# Altium schematic sheet Memo.SchDoc(Memo)
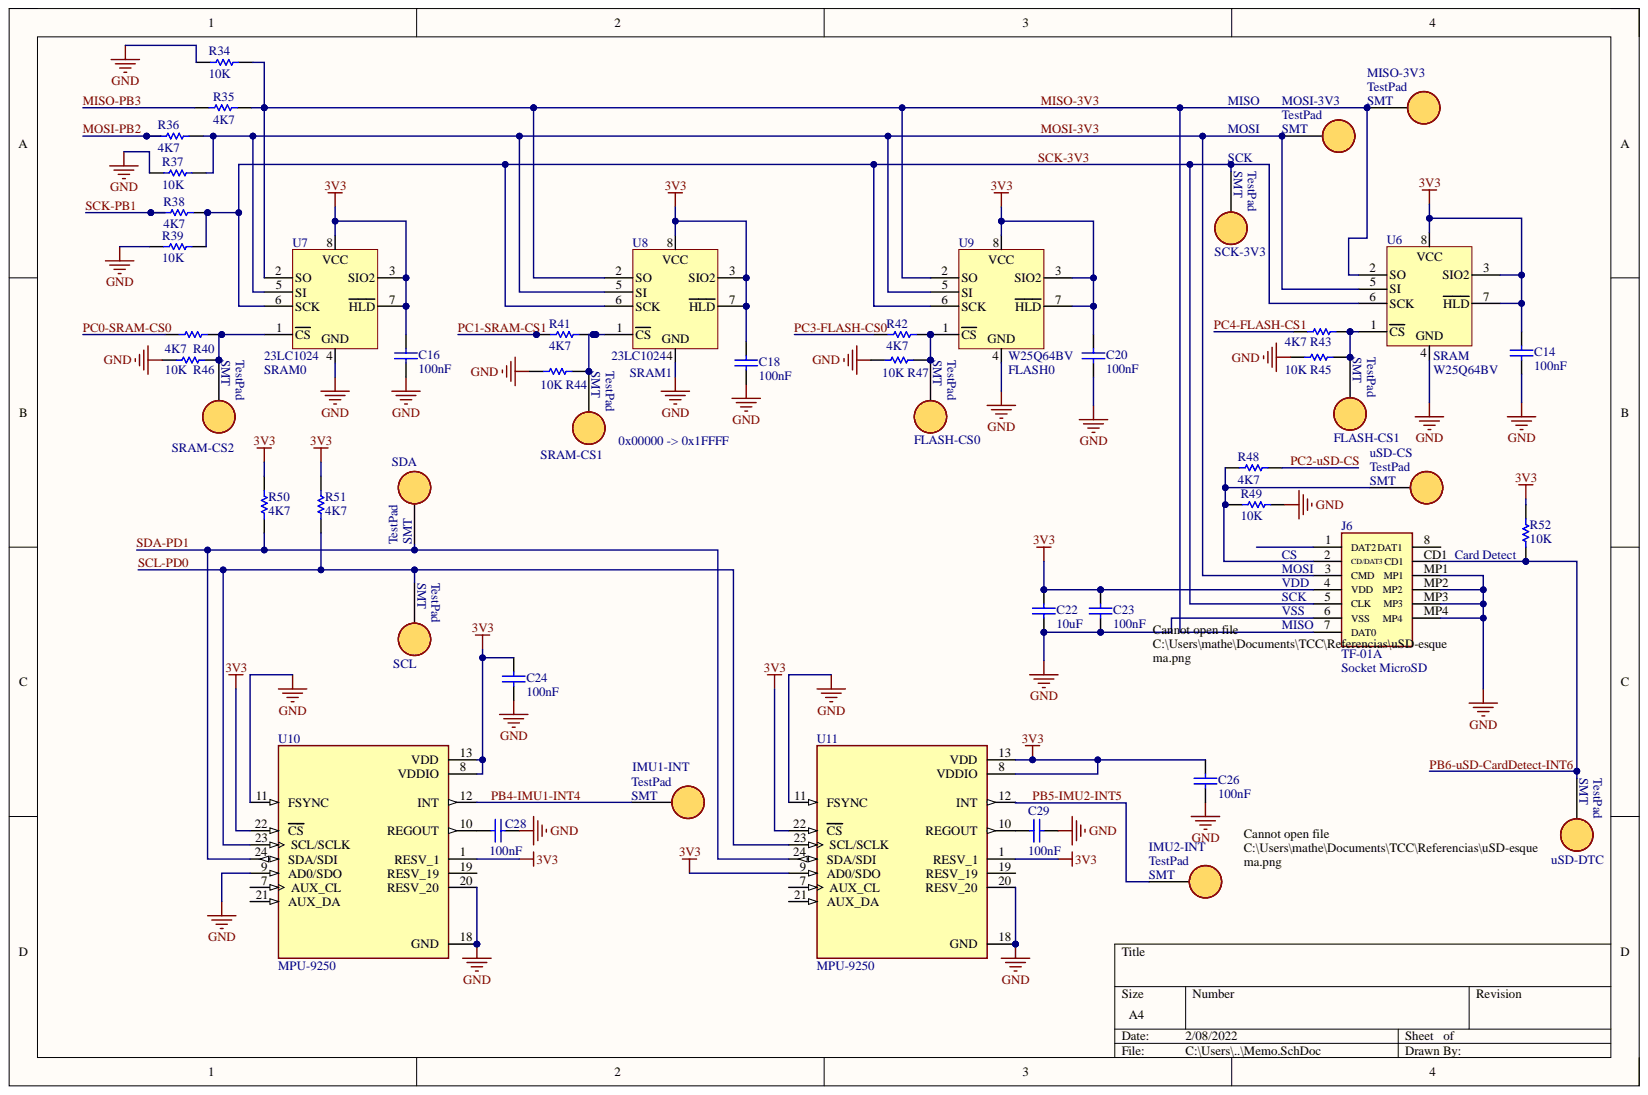
[diagram p0: Memo.SchDoc]

## Parts
C14  100nF  [CAPC2012X65N]
C16  100nF  [CAPC2012X65N]
C18  100nF  [CAPC2012X65N]
C20  100nF  [CAPC2012X65N]
C22  10uF  [CAPGC2012X65N]
C23  100nF  [CAPC2012X65N]
C24  100nF  [CAPC2012X65N]
C26  100nF  [CAPC2012X65N]
C28  100nF  [CAPC2012X65N]
C29  100nF  [CAPC2012X65N]
FLASH-CS0  (no value)  [TestPAD-SMT-SIMPLES]
FLASH-CS1  (no value)  [TestPAD-SMT-SIMPLES]
IMU1-INT  (no value)  [TestPAD-SMT-SIMPLES]
IMU2-INT  (no value)  [TestPAD-SMT-SIMPLES]
J6  TF-01A  [TF01A]
MISO-3V3  (no value)  [TestPAD-SMT-SIMPLES]
MOSI-3V3  (no value)  [TestPAD-SMT-SIMPLES]
R34  10K  [RESC2012X65N]
R35  4K7  [RESC2012X65N]
R36  4K7  [RESC2012X65N]
R37  10K  [RESC2012X65N]
R38  4K7  value 10K  [RESC2012X65N]
R39  10K  [RESC2012X65N]
R40  4K7  [RESC2012X65N]
R41  4K7  [RESC2012X65N]
R42  4K7  [RESC2012X65N]
R43  4K7  [RESC2012X65N]
R44  10K  [RESC2012X65N]
R45  10K  [RESC2012X65N]
R46  10K  [RESC2012X65N]
R47  10K  [RESC2012X65N]
R48  4K7  [RESC2012X65N]
R49  10K  [RESC2012X65N]
R50  4K7  [RESC2012X65N]
R51  4K7  [RESC2012X65N]
R52  10K  [RESC2012X65N]
SCK-3V3  (no value)  [TestPAD-SMT-SIMPLES]
SCL  (no value)  [TestPAD-SMT-SIMPLES]
SDA  (no value)  [TestPAD-SMT-SIMPLES]
SRAM-CS1  (no value)  [TestPAD-SMT-SIMPLES]
SRAM-CS2  (no value)  [TestPAD-SMT-SIMPLES]
U6  W25Q64BV  [SOIC127P790X216-8N]
U7  23LC1024  [SOIC127P600X175-8N]
U8  23LC1024  [SOIC127P600X175-8N]
U9  W25Q64BV  [SOIC127P790X216-8N]
U10  MPU-9250  [QFN40P300X300X105-24N]
U11  MPU-9250  [QFN40P300X300X105-24N]
uSD-CS  (no value)  [TestPAD-SMT-SIMPLES]
uSD-DTC  (no value)  [TestPAD-SMT-SIMPLES]

## Nets
3V3: C14.1 C16.1 C18.1 C20.1 C22.1 C23.1 C24.1 C26.1 J6.4(VDD) R50.2 R51.2 R52.2 U6.3(SIO2) U6.7(HLD) U6.8 U7.3(SIO2) U7.7(HLD) U7.8 U8.3(SIO2) U8.7(HLD) U8.8 U9.3(SIO2) U9.7(HLD) U9.8 U10.1(RESV_1) U10.8(VDDIO) U10.13(VDD) U10.22(CS) U11.1(RESV_1) U11.8(VDDIO) U11.9(AD0/SDO) U11.13(VDD) U11.22(CS)
GND: C14.2 C16.2 C18.2 C20.2 C22.2 C23.2 C24.2 C26.2 C28.2 C29.2 J6.6(VSS) J6.MP1 J6.MP2 J6.MP3 J6.MP4 R34.1 R37.1 R39.1 R44.2 R45.2 R46.2 R47.2 R49.2 U6.4 U7.4 U8.4 U9.4 U10.9(AD0/SDO) U10.11(FSYNC) U10.18(GND) U10.20(RESV_20) U11.11(FSYNC) U11.18(GND) U11.20(RESV_20)
MISO-3V3: J6.7(DAT0) MISO-3V3.1 R34.2 R35.2 U6.2(SO) U7.2(SO) U8.2(SO) U9.2(SO)
MISO-PB3: R35.1
MOSI-3V3: J6.3(CMD) MOSI-3V3.1 R36.1 R37.2 U6.5(SI) U7.5(SI) U8.5(SI) U9.5(SI)
MOSI-PB2: R36.2
PB4-IMU1-INT4: IMU1-INT.1 U10.12(INT)
PB5-IMU2-INT5: IMU2-INT.1 U11.12(INT)
PB6-uSD-CardDetect-INT6: J6.CD1 R52.1 uSD-DTC.1
PC0-SRAM-CS0: R40.1
PC1-SRAM-CS1: R41.1
PC2-uSD-CS: R48.1
PC3-FLASH-CS0: R42.1
PC4-FLASH-CS1: R43.1
SCK-3V3: J6.5(CLK) R38.1 R39.2 SCK-3V3.1 U6.6(SCK) U7.6(SCK) U8.6(SCK) U9.6(SCK)
SCK-PB1: R38.2
SCL-PD0: R51.1 SCL.1 U10.23(SCL/SCLK) U11.23(SCL/SCLK)
SDA-PD1: R50.1 SDA.1 U10.24(SDA/SDI) U11.24(SDA/SDI)
NetC28_1: C28.1 U10.10(REGOUT)
NetC29_1: C29.1 U11.10(REGOUT)
NetFLASH-CS0_1: FLASH-CS0.1 R42.2 R47.1 U9.1(CS)
NetFLASH-CS1_1: FLASH-CS1.1 R43.2 R45.1 U6.1(CS)
NetJ6_2: J6.2(CD/DAT3) R48.2 R49.1 uSD-CS.1
NetR40_2: R40.2 R46.1 SRAM-CS2.1 U7.1(CS)
NetR41_2: R41.2 R44.1 SRAM-CS1.1 U8.1(CS)

Not connected: J6 1(DAT2) 8(DAT1); U10 7(AUX_CL) 19(RESV_19) 21(AUX_DA); U11 7(AUX_CL) 19(RESV_19) 21(AUX_DA)

## Library data of the parts
C14 C16 C18 C20 C23 C24 C26 C28 C29 = Cap: Capacitor; Component Kind: Standard; LatestRevisionDate: 17-Jul-2002; LatestRevisionNote: Re-released for DXP Platform.; Library Name: Miscellaneous Devices.IntLib; PackageDescription: Radial Cap, Thru-Hole; 2 Leads; 0.3 in Pin Spacing; PackageReference: RAD-0.3; Published: 8-Jun-2000; Value: 100nF; Publisher: Altium Limited
C22 = Cap: Capacitor; Value: 100nF; Component Kind: Standard; LatestRevisionDate: 17-Jul-2002; LatestRevisionNote: Re-released for DXP Platform.; Library Name: Miscellaneous Devices.IntLib; PackageDescription: Radial Cap, Thru-Hole; 2 Leads; 0.3 in Pin Spacing; PackageReference: RAD-0.3; Published: 8-Jun-2000; Publisher: Altium Limited
FLASH-CS0 FLASH-CS1 IMU1-INT IMU2-INT MISO-3V3 MOSI-3V3 SCK-3V3 SCL SDA SRAM-CS1 SRAM-CS2 uSD-CS uSD-DTC = TestPad: Component Kind: Standard; Library Name: TestPadSMT.SchLib
J6 = TF-01A: Connector; Manufacturer_Name: Korean Hroparts Elec; Component Kind: Standard; Datasheet Link: https://datasheet.lcsc.com/szlcsc/1903221101_Korean-Hroparts-Elec-TF-01A_C91145.pdf; Height: 1.85mm; Manufacturer_Part_Number: TF-01A
R34 R37-R39 R50-R52 = Res1: Resistor; Component Kind: Standard; LatestRevisionDate: 17-Jul-2002; LatestRevisionNote: Re-released for DXP Platform.; Library Name: Miscellaneous Devices.IntLib; PackageDescription: Axial Device, Thru-Hole; 2 Leads; 0.3 in Pin Spacing; PackageReference: AXIAL-0.3; Published: 8-Jun-2000; Signal Integrity: Res; Simulation: RESISTOR; Publisher: Altium Limited
  R34 R37 R39 R52: Value: 10K
  R50 R51: Value: 4K7
R35 R36 = Res1: Resistor; Value: 10K; Component Kind: Standard; LatestRevisionDate: 17-Jul-2002; LatestRevisionNote: Re-released for DXP Platform.; Library Name: Miscellaneous Devices.IntLib; PackageDescription: Axial Device, Thru-Hole; 2 Leads; 0.3 in Pin Spacing; PackageReference: AXIAL-0.3; Published: 8-Jun-2000; Signal Integrity: Res; Simulation: RESISTOR; Publisher: Altium Limited
R40-R49 = Res1: Resistor; Value: 47K; Component Kind: Standard; LatestRevisionDate: 17-Jul-2002; LatestRevisionNote: Re-released for DXP Platform.; Library Name: Miscellaneous Devices.IntLib; PackageDescription: Axial Device, Thru-Hole; 2 Leads; 0.3 in Pin Spacing; PackageReference: AXIAL-0.3; Published: 8-Jun-2000; Signal Integrity: Res; Simulation: RESISTOR; Publisher: Altium Limited
U6 = W25Q64BV: FLASH 8MB; PCB Footprint: SOIC-8; Component Kind: Standard; Library Name: Zele_Sch.SchLib
U7 U8 = 23LC1024: SRAM 128KB; Component Kind: Standard; Library Name: Zele_Sch.SchLib
U9 = W25Q64BV: FLASH 8MB; PCB Footprint: DIP8; Component Kind: Standard; Library Name: Zele_Sch.SchLib
U10 U11 = MPU-9250: Accelerometer, Gyroscope, Magnetometer, 9 Axis Sensor IÂ²C, SPI Output; MF: TDK InvenSense; Availability: Unavailable; Component Kind: Standard; Library Name: MPU9250.SchLib; MP: MPU-9250; Package: TFQFN-24 TDK InvenSense; Price: None; SnapEDA_Link: https://www.snapeda.com/parts/MPU-9250/TDK%20InvenSense/view-part/4652549/?ref=snap
